# Altium schematic sheet CSI2-ZCU106-EVK-1_Rev01.SchDoc
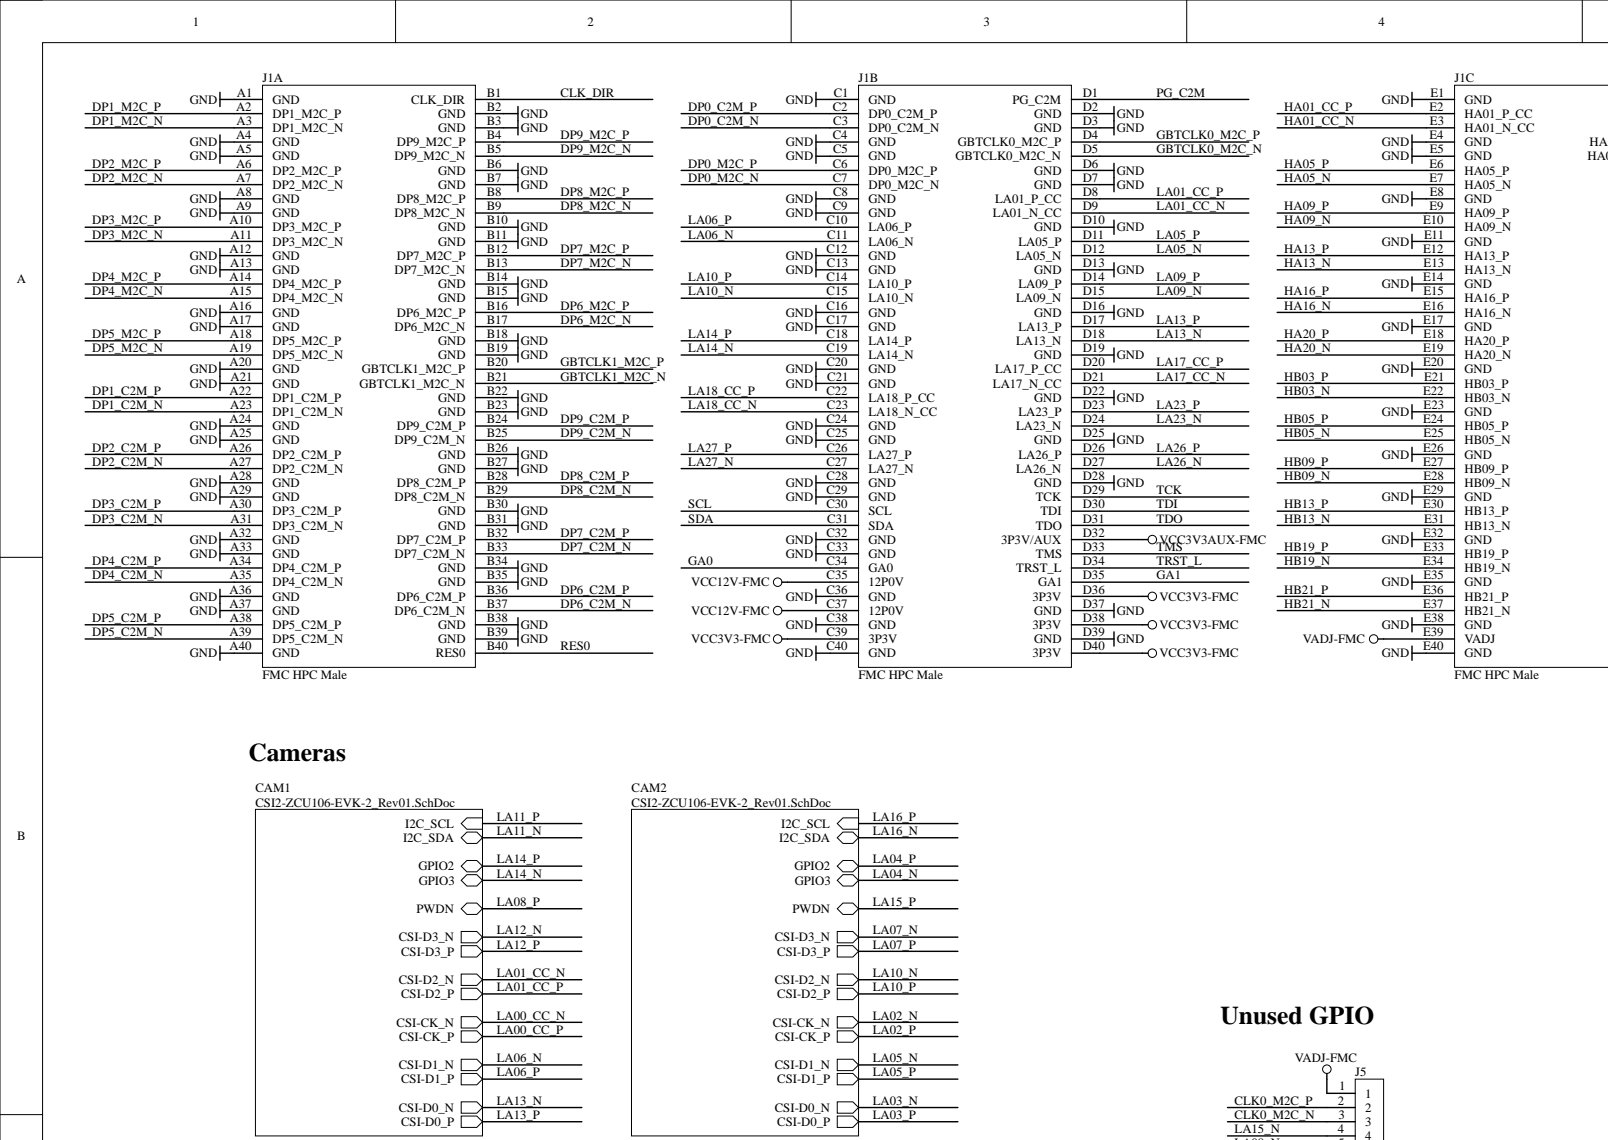
[diagram p0: CSI2-ZCU106-EVK-1_Rev01.SchDoc, top left]
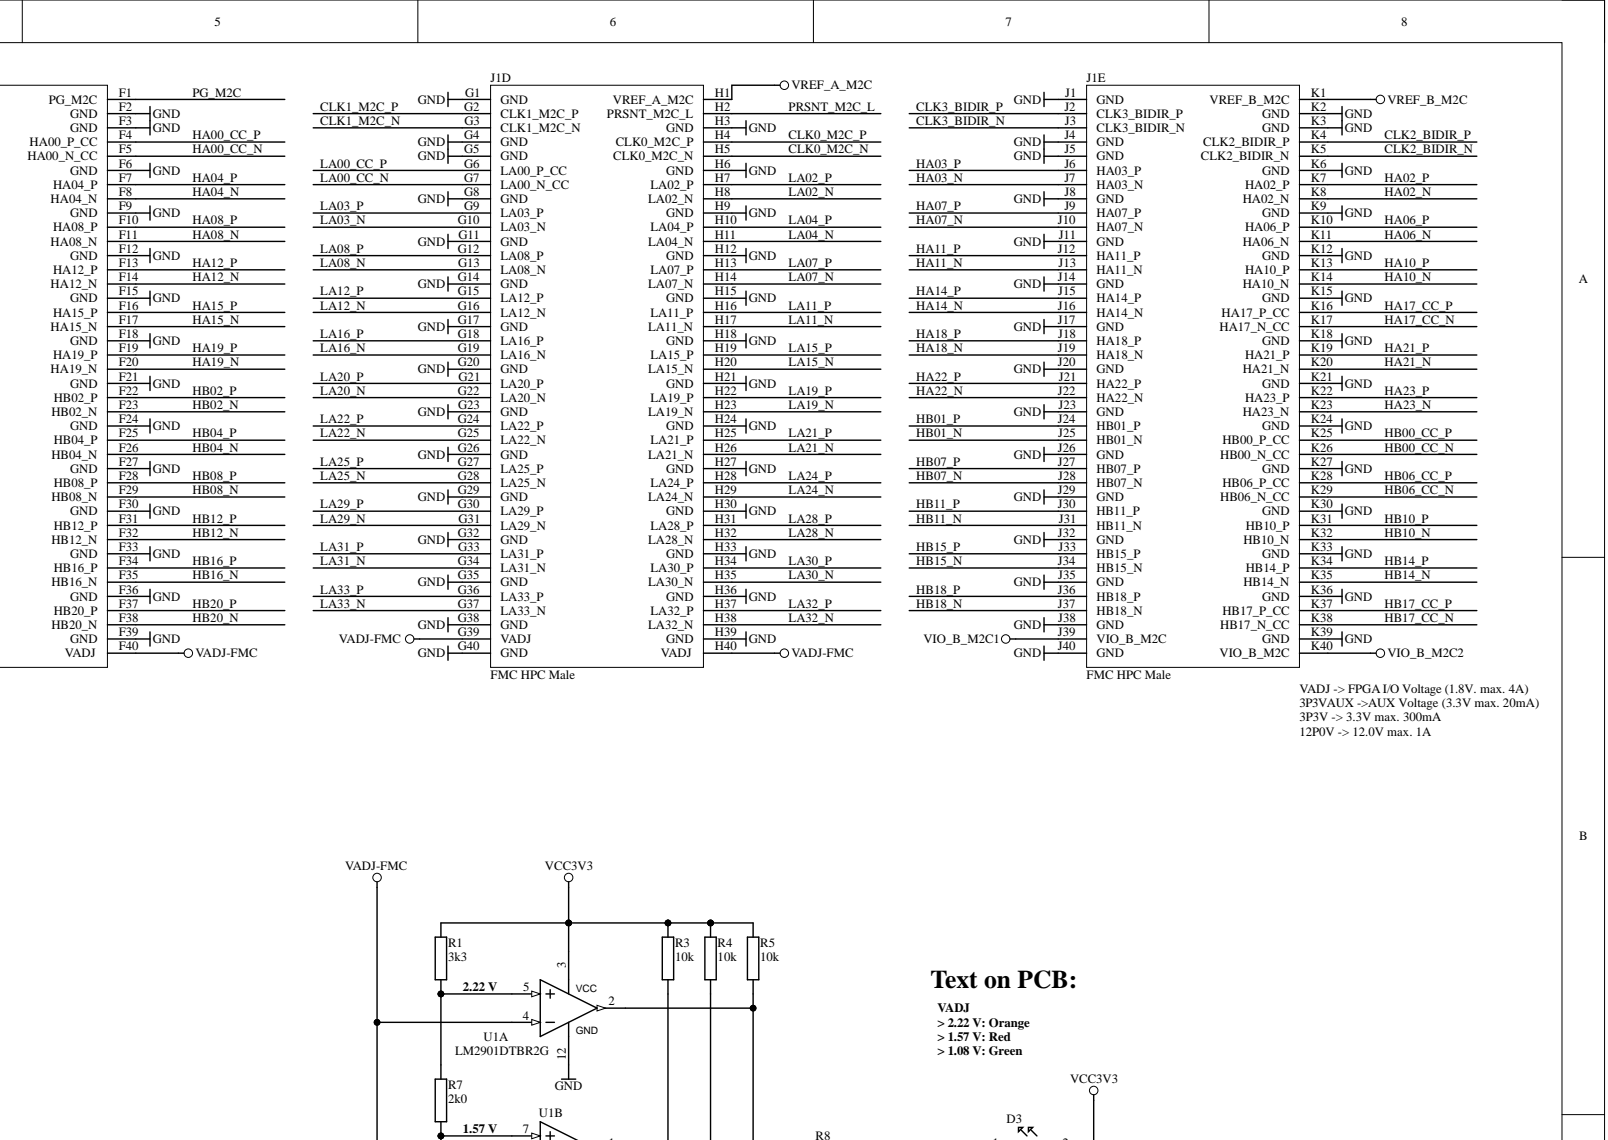
[diagram p1: CSI2-ZCU106-EVK-1_Rev01.SchDoc, top right]
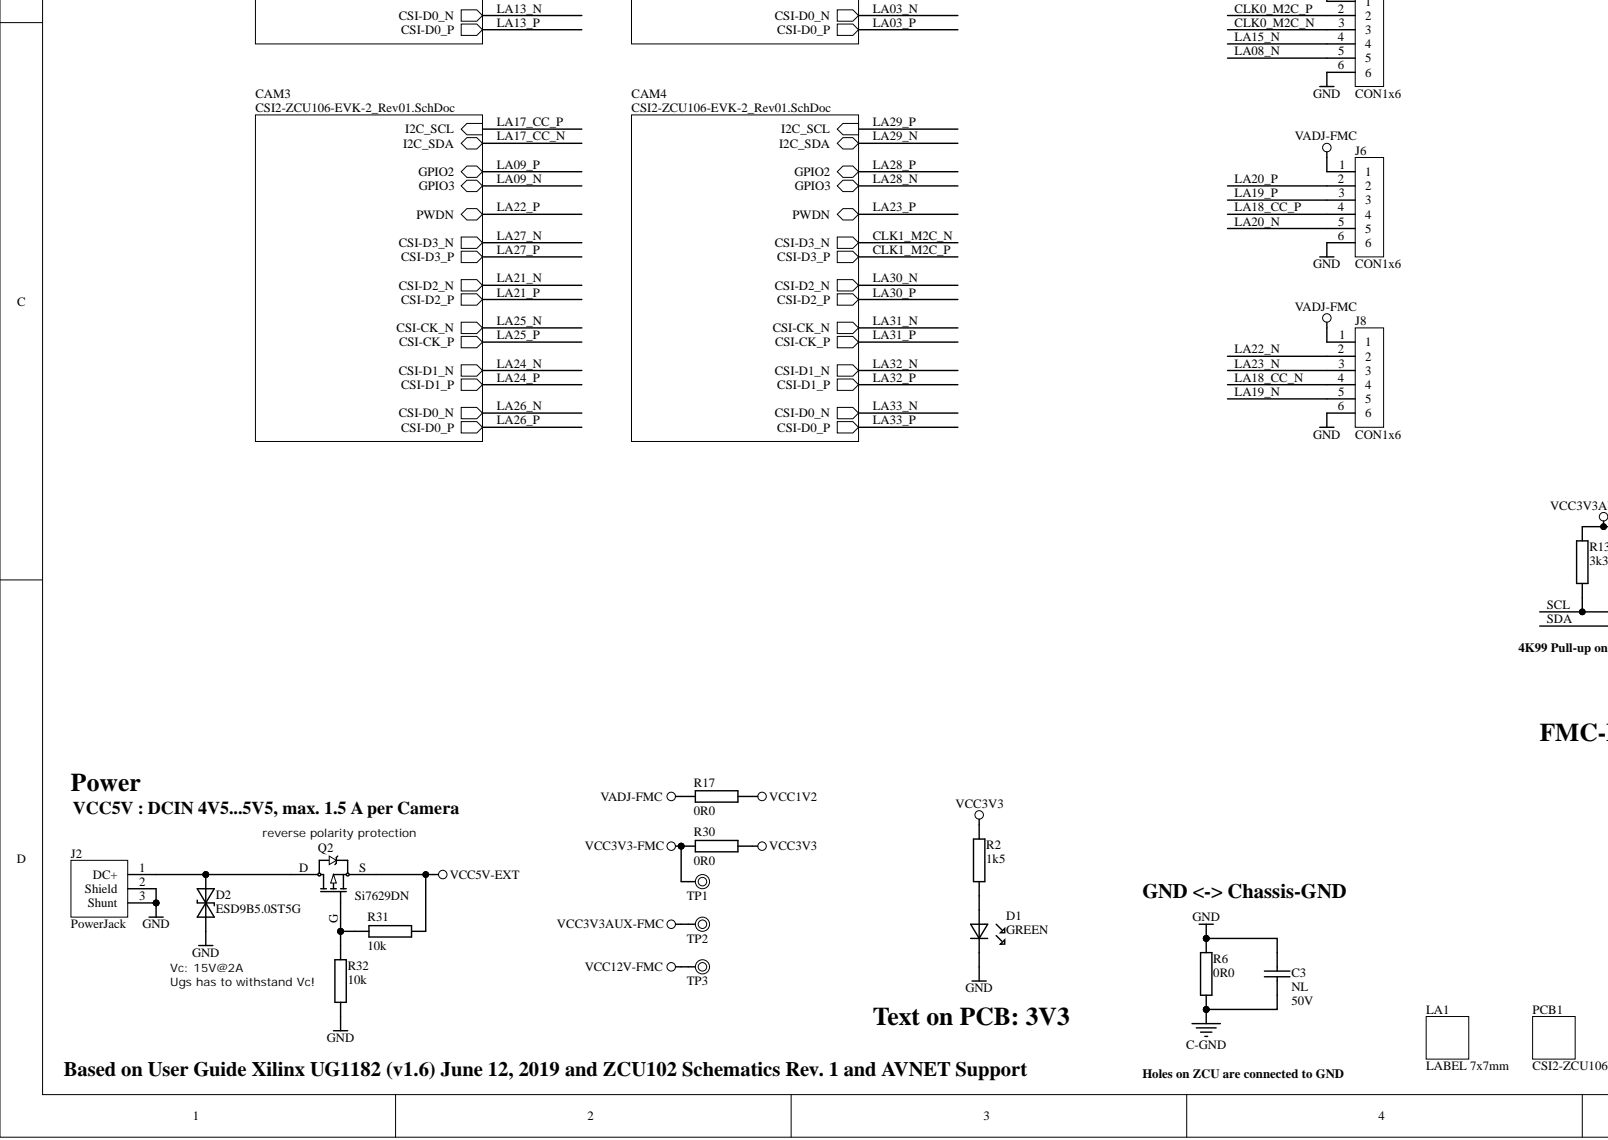
[diagram p2: CSI2-ZCU106-EVK-1_Rev01.SchDoc, bottom left]
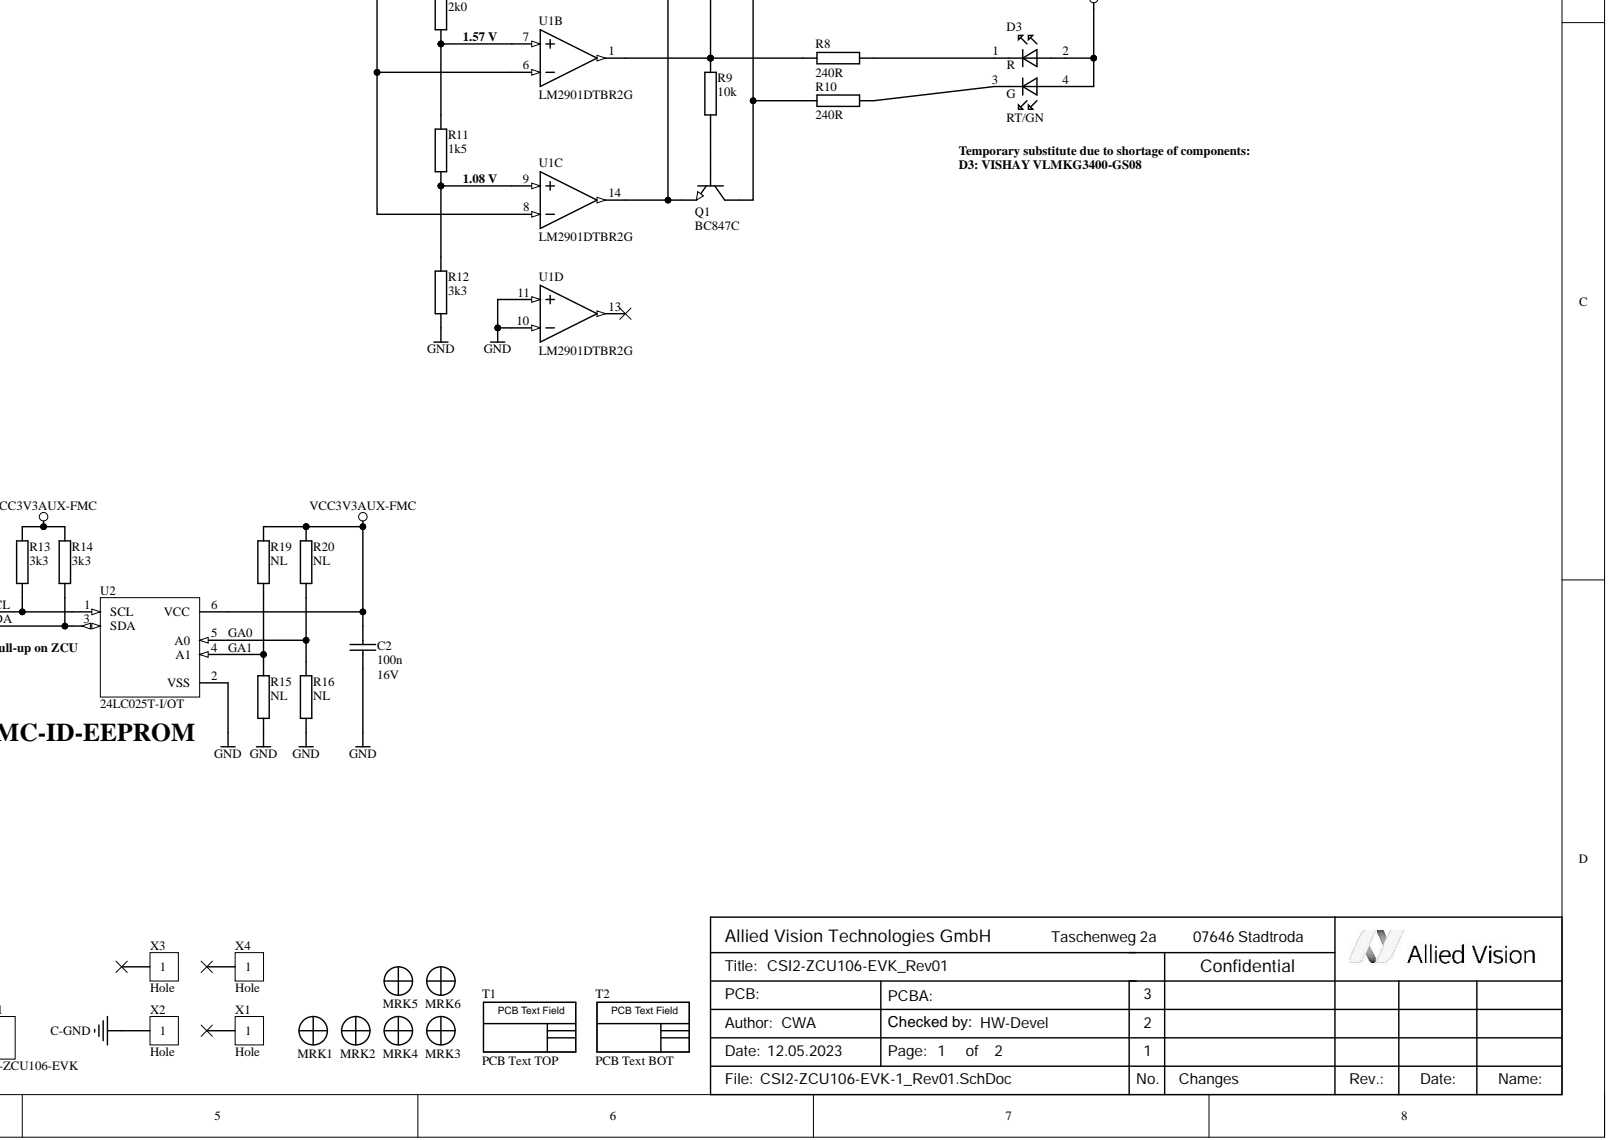
[diagram p3: CSI2-ZCU106-EVK-1_Rev01.SchDoc, bottom right]

## Parts
C2  100n  Voltage: 16V  [C-0402_0.55]
C3  NL  Voltage: 50V  [C-0603_1.0]
D1  GREEN  [D-0603-LED]
D2  ESD9B5.0ST5G  [D-SOD923]
D3  RT/GN  [LED-PLCC4]
J1  FMC HPC Male  [J-ASP-134488-01]  (parts A,B,C,D,E)
J2  PowerJack  [CON_RAPC722]
J5  CON1x6  [CON 1x6 RM2.54]
J6  CON1x6  [CON 1x6 RM2.54]
J8  CON1x6  [CON 1x6 RM2.54]
LA1  LABEL 7x7mm  [PCB LABEL 7x7]
MRK1  Marker Single Layer  [PCB_Marker_Pad_top]  (value not drawn)
MRK2  Marker Single Layer  [PCB_Marker_Pad_top]  (value not drawn)
MRK3  Marker Single Layer  [PCB_Marker_Pad_top]  (value not drawn)
MRK4  Marker Single Layer  [PCB_Marker_Pad_top]  (value not drawn)
MRK5  Marker Single Layer  [PCB_Marker_Pad_top]  (value not drawn)
MRK6  Marker Single Layer  [PCB_Marker_Pad_top]  (value not drawn)
PCB1  CSI2-ZCU106-EVK  (mechanical)
Q1  BC847C  [IC-SOT23-3]
Q2  Si7629DN  [IC-POWERPAK_1212-8_3.4x3.4x1.1]
R1  3k3  [R-0402_0.4]
R2  1k5  [R-0402_0.4]
R3  10k  [R-0402_0.4]
R4  10k  [R-0402_0.4]
R5  10k  [R-0402_0.4]
R6  0R0  [R-0603_0.6]
R7  2k0  [R-0402_0.4]
R8  240R  [R-0402_0.4]
R9  10k  [R-0402_0.4]
R10  240R  [R-0402_0.4]
R11  1k5  [R-0402_0.4]
R12  3k3  [R-0402_0.4]
R13  3k3  [R-0402_0.4]
R14  3k3  [R-0402_0.4]
R15  NL  [R-0603_0.6]
R16  NL  [R-0603_0.6]
R17  0R0  [R-0603_0.6]
R19  NL  [R-0603_0.6]
R20  NL  [R-0603_0.6]
R30  0R0  [R-0603_0.6]
R31  10k  [R-0402_0.4]
R32  10k  [R-0402_0.4]
T1  PCB Text TOP  [PCB TEXTFIELD TOP 2]  (no BOM)
T2  PCB Text BOT  [PCB TEXTFIELD BOT 2]  (no BOM)
TP1  TP  [TP_16_10]  (no BOM)
TP2  TP  [TP_16_10]  (no BOM)
TP3  TP  [TP_16_10]  (no BOM)
U1  LM2901DTBR2G  [IC-SO14_5.1x6.6x1.2]  (parts A,B,C,D)
U2  24LC025T-I/OT  [IC-SOT23-6]
X1  Hole  [HOLE-2.6-5.0-DK]  (no BOM)
X2  Hole  [HOLE-2.6-5.0-DK]  (no BOM)
X3  Hole  [HOLE-2.6-5.0-DK]  (no BOM)
X4  Hole  [HOLE-2.6-5.0-DK]  (no BOM)

## Nets
C-GND: C3.2 R6.1 X2.1
GND: C2.2 C3.1 D1.K D2.A J1.A1(GND) J1.A4(GND) J1.A5(GND) J1.A8(GND) J1.A9(GND) J1.A12(GND) J1.A13(GND) J1.A16(GND) J1.A17(GND) J1.A20(GND) J1.A21(GND) J1.A24(GND) J1.A25(GND) J1.A28(GND) J1.A29(GND) J1.A32(GND) J1.A33(GND) J1.A36(GND) J1.A37(GND) J1.A40(GND) J1.B2(GND) J1.B3(GND) J1.B6(GND) J1.B7(GND) J1.B10(GND) J1.B11(GND) J1.B14(GND) J1.B15(GND) J1.B18(GND) J1.B19(GND) J1.B22(GND) J1.B23(GND) J1.B26(GND) J1.B27(GND) J1.B30(GND) J1.B31(GND) J1.B34(GND) J1.B35(GND) J1.B38(GND) J1.B39(GND) J1.C1(GND) J1.C4(GND) J1.C5(GND) J1.C8(GND) J1.C9(GND) J1.C12(GND) J1.C13(GND) J1.C16(GND) J1.C17(GND) J1.C20(GND) J1.C21(GND) J1.C24(GND) J1.C25(GND) J1.C28(GND) J1.C29(GND) J1.C32(GND) J1.C33(GND) J1.C36(GND) J1.C38(GND) J1.C40(GND) J1.D2(GND) J1.D3(GND) J1.D6(GND) J1.D7(GND) J1.D10(GND) J1.D13(GND) J1.D16(GND) J1.D19(GND) J1.D22(GND) J1.D25(GND) J1.D28(GND) J1.D37(GND) J1.D39(GND) J1.E1(GND) J1.E4(GND) J1.E5(GND) J1.E8(GND) J1.E11(GND) J1.E14(GND) J1.E17(GND) J1.E20(GND) J1.E23(GND) J1.E26(GND) J1.E29(GND) J1.E32(GND) J1.E35(GND) J1.E38(GND) J1.E40(GND) J1.F2(GND) J1.F3(GND) J1.F6(GND) J1.F9(GND) J1.F12(GND) J1.F15(GND) J1.F18(GND) J1.F21(GND) J1.F24(GND) J1.F27(GND) J1.F30(GND) J1.F33(GND) J1.F36(GND) J1.F39(GND) J1.G1(GND) J1.G4(GND) J1.G5(GND) J1.G8(GND) J1.G11(GND) J1.G14(GND) J1.G17(GND) J1.G20(GND) J1.G23(GND) J1.G26(GND) J1.G29(GND) J1.G32(GND) J1.G35(GND) J1.G38(GND) J1.G40(GND) J1.H3(GND) J1.H6(GND) J1.H9(GND) J1.H12(GND) J1.H15(GND) J1.H18(GND) J1.H21(GND) J1.H24(GND) J1.H27(GND) J1.H30(GND) J1.H33(GND) J1.H36(GND) J1.H39(GND) J1.J1(GND) J1.J4(GND) J1.J5(GND) J1.J8(GND) J1.J11(GND) J1.J14(GND) J1.J17(GND) J1.J20(GND) J1.J23(GND) J1.J26(GND) J1.J29(GND) J1.J32(GND) J1.J35(GND) J1.J38(GND) J1.J40(GND) J1.K2(GND) J1.K3(GND) J1.K6(GND) J1.K9(GND) J1.K12(GND) J1.K15(GND) J1.K18(GND) J1.K21(GND) J1.K24(GND) J1.K27(GND) J1.K30(GND) J1.K33(GND) J1.K36(GND) J1.K39(GND) J2.2 J2.3 J5.6 J6.6 J8.6 R6.2 R12.2 R15.2 R16.2 R32.1 U1.10 U1.11 U1.12 U2.2(VSS)
VADJ-FMC: J1.E39(VADJ) J1.F40(VADJ) J1.G39(VADJ) J1.H40(VADJ) J5.1 J6.1 J8.1 R17.1 U1.4 U1.6 U1.8
VCC1V2: R17.2
VCC3V3: D3.2 D3.4 R1.1 R2.1 R3.1 R4.1 R5.1 R30.2 U1.3
VCC3V3-FMC: J1.C39(3P3V) J1.D36(3P3V) J1.D38(3P3V) J1.D40(3P3V) R30.1 TP1.1
VCC3V3AUX-FMC: C2.1 J1.D32(3P3V/AUX) R13.2 R14.2 R19.1 R20.1 TP2.1 U2.6(VCC)
VCC5V-EXT: Q2.S R31.1
VCC12V-FMC: J1.C35(12P0V) J1.C37(12P0V) TP3.1
VIO_B_M2C1: J1.J39(VIO_B_M2C)
VIO_B_M2C2: J1.K40(VIO_B_M2C)
VREF_A_M2C: J1.H1(VREF_A_M2C)
VREF_B_M2C: J1.K1(VREF_B_M2C)
CLK0_M2C_N: J1.H5(CLK0_M2C_N) J5.3
CLK0_M2C_P: J1.H4(CLK0_M2C_P) J5.2
CLK1_M2C_N: J1.G3(CLK1_M2C_N)
CLK1_M2C_P: J1.G2(CLK1_M2C_P)
CLK2_BIDIR_N: J1.K5(CLK2_BIDIR_N)
CLK2_BIDIR_P: J1.K4(CLK2_BIDIR_P)
CLK3_BIDIR_N: J1.J3(CLK3_BIDIR_N)
CLK3_BIDIR_P: J1.J2(CLK3_BIDIR_P)
CLK_DIR: J1.B1(CLK_DIR)
DP0_C2M_N: J1.C3(DP0_C2M_N)
DP0_C2M_P: J1.C2(DP0_C2M_P)
DP0_M2C_N: J1.C7(DP0_M2C_N)
DP0_M2C_P: J1.C6(DP0_M2C_P)
DP1_C2M_N: J1.A23(DP1_C2M_N)
DP1_C2M_P: J1.A22(DP1_C2M_P)
DP1_M2C_N: J1.A3(DP1_M2C_N)
DP1_M2C_P: J1.A2(DP1_M2C_P)
DP2_C2M_N: J1.A27(DP2_C2M_N)
DP2_C2M_P: J1.A26(DP2_C2M_P)
DP2_M2C_N: J1.A7(DP2_M2C_N)
DP2_M2C_P: J1.A6(DP2_M2C_P)
DP3_C2M_N: J1.A31(DP3_C2M_N)
DP3_C2M_P: J1.A30(DP3_C2M_P)
DP3_M2C_N: J1.A11(DP3_M2C_N)
DP3_M2C_P: J1.A10(DP3_M2C_P)
DP4_C2M_N: J1.A35(DP4_C2M_N)
DP4_C2M_P: J1.A34(DP4_C2M_P)
DP4_M2C_N: J1.A15(DP4_M2C_N)
DP4_M2C_P: J1.A14(DP4_M2C_P)
DP5_C2M_N: J1.A39(DP5_C2M_N)
DP5_C2M_P: J1.A38(DP5_C2M_P)
DP5_M2C_N: J1.A19(DP5_M2C_N)
DP5_M2C_P: J1.A18(DP5_M2C_P)
DP6_C2M_N: J1.B37(DP6_C2M_N)
DP6_C2M_P: J1.B36(DP6_C2M_P)
DP6_M2C_N: J1.B17(DP6_M2C_N)
DP6_M2C_P: J1.B16(DP6_M2C_P)
DP7_C2M_N: J1.B33(DP7_C2M_N)
DP7_C2M_P: J1.B32(DP7_C2M_P)
DP7_M2C_N: J1.B13(DP7_M2C_N)
DP7_M2C_P: J1.B12(DP7_M2C_P)
DP8_C2M_N: J1.B29(DP8_C2M_N)
DP8_C2M_P: J1.B28(DP8_C2M_P)
DP8_M2C_N: J1.B9(DP8_M2C_N)
DP8_M2C_P: J1.B8(DP8_M2C_P)
DP9_C2M_N: J1.B25(DP9_C2M_N)
DP9_C2M_P: J1.B24(DP9_C2M_P)
DP9_M2C_N: J1.B5(DP9_M2C_N)
DP9_M2C_P: J1.B4(DP9_M2C_P)
GA0: J1.C34(GA0) R16.1 R20.2 U2.5(A0)
GA1: J1.D35(GA1) R15.1 R19.2 U2.4(A1)
GBTCLK0_M2C_N: J1.D5(GBTCLK0_M2C_N)
GBTCLK0_M2C_P: J1.D4(GBTCLK0_M2C_P)
GBTCLK1_M2C_N: J1.B21(GBTCLK1_M2C_N)
GBTCLK1_M2C_P: J1.B20(GBTCLK1_M2C_P)
HA00_CC_N: J1.F5(HA00_N_CC)
HA00_CC_P: J1.F4(HA00_P_CC)
HA01_CC_N: J1.E3(HA01_N_CC)
HA01_CC_P: J1.E2(HA01_P_CC)
HA02_N: J1.K8(HA02_N)
HA02_P: J1.K7(HA02_P)
HA03_N: J1.J7(HA03_N)
HA03_P: J1.J6(HA03_P)
HA04_N: J1.F8(HA04_N)
HA04_P: J1.F7(HA04_P)
HA05_N: J1.E7(HA05_N)
HA05_P: J1.E6(HA05_P)
HA06_N: J1.K11(HA06_N)
HA06_P: J1.K10(HA06_P)
HA07_N: J1.J10(HA07_N)
HA07_P: J1.J9(HA07_P)
HA08_N: J1.F11(HA08_N)
HA08_P: J1.F10(HA08_P)
HA09_N: J1.E10(HA09_N)
HA09_P: J1.E9(HA09_P)
HA10_N: J1.K14(HA10_N)
HA10_P: J1.K13(HA10_P)
HA11_N: J1.J13(HA11_N)
HA11_P: J1.J12(HA11_P)
HA12_N: J1.F14(HA12_N)
HA12_P: J1.F13(HA12_P)
HA13_N: J1.E13(HA13_N)
HA13_P: J1.E12(HA13_P)
HA14_N: J1.J16(HA14_N)
HA14_P: J1.J15(HA14_P)
HA15_N: J1.F17(HA15_N)
HA15_P: J1.F16(HA15_P)
HA16_N: J1.E16(HA16_N)
HA16_P: J1.E15(HA16_P)
HA17_CC_N: J1.K17(HA17_N_CC)
HA17_CC_P: J1.K16(HA17_P_CC)
HA18_N: J1.J19(HA18_N)
HA18_P: J1.J18(HA18_P)
HA19_N: J1.F20(HA19_N)
HA19_P: J1.F19(HA19_P)
HA20_N: J1.E19(HA20_N)
HA20_P: J1.E18(HA20_P)
HA21_N: J1.K20(HA21_N)
HA21_P: J1.K19(HA21_P)
HA22_N: J1.J22(HA22_N)
HA22_P: J1.J21(HA22_P)
HA23_N: J1.K23(HA23_N)
HA23_P: J1.K22(HA23_P)
HB00_CC_N: J1.K26(HB00_N_CC)
HB00_CC_P: J1.K25(HB00_P_CC)
HB01_N: J1.J25(HB01_N)
HB01_P: J1.J24(HB01_P)
HB02_N: J1.F23(HB02_N)
HB02_P: J1.F22(HB02_P)
HB03_N: J1.E22(HB03_N)
HB03_P: J1.E21(HB03_P)
HB04_N: J1.F26(HB04_N)
HB04_P: J1.F25(HB04_P)
HB05_N: J1.E25(HB05_N)
HB05_P: J1.E24(HB05_P)
HB06_CC_N: J1.K29(HB06_N_CC)
HB06_CC_P: J1.K28(HB06_P_CC)
HB07_N: J1.J28(HB07_N)
HB07_P: J1.J27(HB07_P)
HB08_N: J1.F29(HB08_N)
HB08_P: J1.F28(HB08_P)
HB09_N: J1.E28(HB09_N)
HB09_P: J1.E27(HB09_P)
HB10_N: J1.K32(HB10_N)
HB10_P: J1.K31(HB10_P)
HB11_N: J1.J31(HB11_N)
HB11_P: J1.J30(HB11_P)
HB12_N: J1.F32(HB12_N)
HB12_P: J1.F31(HB12_P)
HB13_N: J1.E31(HB13_N)
HB13_P: J1.E30(HB13_P)
HB14_N: J1.K35(HB14_N)
HB14_P: J1.K34(HB14_P)
HB15_N: J1.J34(HB15_N)
HB15_P: J1.J33(HB15_P)
HB16_N: J1.F35(HB16_N)
HB16_P: J1.F34(HB16_P)
HB17_CC_N: J1.K38(HB17_N_CC)
HB17_CC_P: J1.K37(HB17_P_CC)
HB18_N: J1.J37(HB18_N)
HB18_P: J1.J36(HB18_P)
HB19_N: J1.E34(HB19_N)
HB19_P: J1.E33(HB19_P)
HB20_N: J1.F38(HB20_N)
HB20_P: J1.F37(HB20_P)
HB21_N: J1.E37(HB21_N)
HB21_P: J1.E36(HB21_P)
LA00_CC_N: J1.G7(LA00_N_CC)
LA00_CC_P: J1.G6(LA00_P_CC)
LA01_CC_N: J1.D9(LA01_N_CC)
LA01_CC_P: J1.D8(LA01_P_CC)
LA02_N: J1.H8(LA02_N)
LA02_P: J1.H7(LA02_P)
LA03_N: J1.G10(LA03_N)
LA03_P: J1.G9(LA03_P)
LA04_N: J1.H11(LA04_N)
LA04_P: J1.H10(LA04_P)
LA05_N: J1.D12(LA05_N)
LA05_P: J1.D11(LA05_P)
LA06_N: J1.C11(LA06_N)
LA06_P: J1.C10(LA06_P)
LA07_N: J1.H14(LA07_N)
LA07_P: J1.H13(LA07_P)
LA08_N: J1.G13(LA08_N) J5.5
LA08_P: J1.G12(LA08_P)
LA09_N: J1.D15(LA09_N)
LA09_P: J1.D14(LA09_P)
LA10_N: J1.C15(LA10_N)
LA10_P: J1.C14(LA10_P)
LA11_N: J1.H17(LA11_N)
LA11_P: J1.H16(LA11_P)
LA12_N: J1.G16(LA12_N)
LA12_P: J1.G15(LA12_P)
LA13_N: J1.D18(LA13_N)
LA13_P: J1.D17(LA13_P)
LA14_N: J1.C19(LA14_N)
LA14_P: J1.C18(LA14_P)
LA15_N: J1.H20(LA15_N) J5.4
LA15_P: J1.H19(LA15_P)
LA16_N: J1.G19(LA16_N)
LA16_P: J1.G18(LA16_P)
LA17_CC_N: J1.D21(LA17_N_CC)
LA17_CC_P: J1.D20(LA17_P_CC)
LA18_CC_N: J1.C23(LA18_N_CC) J8.4
LA18_CC_P: J1.C22(LA18_P_CC) J6.4
LA19_N: J1.H23(LA19_N) J8.5
LA19_P: J1.H22(LA19_P) J6.3
LA20_N: J1.G22(LA20_N) J6.5
LA20_P: J1.G21(LA20_P) J6.2
LA21_N: J1.H26(LA21_N)
LA21_P: J1.H25(LA21_P)
LA22_N: J1.G25(LA22_N) J8.2
LA22_P: J1.G24(LA22_P)
LA23_N: J1.D24(LA23_N) J8.3
LA23_P: J1.D23(LA23_P)
LA24_N: J1.H29(LA24_N)
LA24_P: J1.H28(LA24_P)
LA25_N: J1.G28(LA25_N)
LA25_P: J1.G27(LA25_P)
LA26_N: J1.D27(LA26_N)
LA26_P: J1.D26(LA26_P)
LA27_N: J1.C27(LA27_N)
LA27_P: J1.C26(LA27_P)
LA28_N: J1.H32(LA28_N)
LA28_P: J1.H31(LA28_P)
LA29_N: J1.G31(LA29_N)
LA29_P: J1.G30(LA29_P)
LA30_N: J1.H35(LA30_N)
LA30_P: J1.H34(LA30_P)
LA31_N: J1.G34(LA31_N)
LA31_P: J1.G33(LA31_P)
LA32_N: J1.H38(LA32_N)
LA32_P: J1.H37(LA32_P)
LA33_N: J1.G37(LA33_N)
LA33_P: J1.G36(LA33_P)
PG_C2M: J1.D1(PG_C2M)
PG_M2C: J1.F1(PG_M2C)
PRSNT_M2C_L: J1.H2(PRSNT_M2C_L)
RES0: J1.B40(RES0)
SCL: J1.C30(SCL) R13.1 U2.1(SCL)
SDA: J1.C31(SDA) R14.1 U2.3(SDA)
TCK: J1.D29(TCK)
TDI: J1.D30(TDI)
TDO: J1.D31(TDO)
TMS: J1.D33(TMS)
TRST_L: J1.D34(TRST_L)
NetD1_A: D1.A R2.2
NetD2_K: D2.K J2.1 Q2.D
NetD3_1: D3.1 R8.2
NetD3_3: D3.3 R10.2
NetQ1_1: Q1.1 R9.2
NetQ1_2: Q1.2 R3.2 U1.14
NetQ1_3: Q1.3 R5.2 R10.1 U1.2
NetQ2_G: Q2.G R31.2 R32.2
NetR1_2: R1.2 R7.1 U1.5
NetR4_2: R4.2 R8.1 R9.1 U1.1
NetR7_2: R7.2 R11.1 U1.7
NetR11_2: R11.2 R12.1 U1.9
NetU1_13: U1.13
NetX1_1: X1.1
NetX3_1: X3.1
NetX4_1: X4.1

## Library data of the parts
C2 = C 100N 10% 16V X7R 402: Capacitor Ceramic SMD/0402/16V/X7R/10%; KHK Part Number: K8340114; Manufacturer: several; Dielectric: X7R; Tolerance: 10%; Component Kind: Standard; Library Name: Passive.SCHLIB; Manufacturer Part Number: several; Supplier 1: Digi-Key; Supplier 2: Digi-Key; Supplier Part Number 1: 1276-1508-1-ND; Supplier Part Number 2: 311-1338-1-ND
C3 = C X 0603: Capacitor Ceramic SMD/0603/50V/X7R/10%; Manufacturer: several; Dielectric: X7R; Tolerance: 10%; Component Kind: Standard; Manufacturer Part Number: several; Library Name: AVT_KHK_Lib.IntLib
D1 = D LED 0603 green: Chip LED green 430mcd; KHK Part Number: 8172; Manufacturer: Würth; Manufacturer Part Number: 150060GS75000; Current: 20mA; Color: green; Component Kind: Standard; Supplier 1: Digi-Key; Supplier Part Number 1: 732-4971-1-ND; Library Name: AVT_KHK_Lib.IntLib
D2 = D ESD9B5.0ST5G: DIODE TVS; 400W; 5.8-7.8V; BIDIRECT; KHK Part Number: 7250; Manufacturer: ON Semiconductor; Component Kind: Standard; Manufacturer Part Number: ESD9B5.0ST5G; Supplier 1: Digi-Key; Supplier Part Number 1: ESD9B5.0ST5GOSCT-ND; Library Name: AVT_KHK_Lib.IntLib
D3 = D LSG T67K-JL-1+HK-1: LED red/green; KHK Part Number: 11105; Manufacturer: OSRAM; Component Kind: Standard; Library Name: Bipolar.SCHLIB; Manufacturer Part Number: LSG T67K-JL-1+HK-1; Supplier 1: Digi-Key; Supplier Part Number 1: 475-3435-1-ND
J1 = CON FMC HPC Male: CON FMC HPC MALE 10MM; KHK Part Number: 9159; Manufacturer: Samtec; Manufacturer Part Number: ASP-134488-01; Component Kind: Standard; Library Name: Connector.SCHLIB; Supplier 1: Digi-Key; Supplier Part Number 1: SAM8730-ND
J2 = CON RAPC722: Switchcraft PowerJack RAPC722 / 2.1mm (Inner) / 6mm (Outer); KHK Part Number: K7600556; Manufacturer Part Number: RAPC722X; Component Kind: Standard; Manufacturer: Switchcraft; Supplier 1: Digi-Key; Supplier Part Number 1: SC1313-ND; Library Name: AVT_KHK_Lib.IntLib
J5 J6 J8 = CON 1x6 2.54 several: Header Vertical, 1x6 Pos, Pitch 2.54mm, Contact Length Mating 6.00mm, Contact Length Post 3.00mm, Insulation Height 2.54mm, Gold Plating; KHK Part Number: 15686; Component Kind: Standard; Library Name: Connector.SCHLIB; Manufacturer: several; Manufacturer Part Number: several; Supplier 1: Digi-Key; Supplier Part Number 1: 732-5319-ND
LA1 = PCB LABEL 7x7: Manufacturer: several; Component Kind: Standard; Description: LABEL 7x7mm; Library Name: Misc.SCHLIB; Manufacturer Part Number: several
MRK1-MRK6 = PCB Marker Pad Single Layer: Position Marker for PCBs; Component Kind: Standard; Library Name: AVT_KHK_Lib.IntLib
PCB1 = PCB: PCB ML04SF; KHK Part Number: 14393-01; Manufacturer: several; Manufacturer Part Number: 14393-01; Library Name: AVT_KHK_Lib.IntLib
Q1 = Q BC847C SOT23: TRANSISTOR NPN 100mA 45V; KHK Part Number: 16587; Manufacturer: several; Component Kind: Standard; Library Name: Bipolar.SCHLIB; Manufacturer Part Number: BC847C; Supplier 1: Digi-Key; Supplier Part Number 1: BC847CE6327HTSA1CT-ND
Q2 = Q Si7629DN: P-Channel MOSFET 20V; KHK Part Number: 3619; Manufacturer: Vishay; Component Kind: Standard; Manufacturer Part Number: Si7629DN; Supplier 1: Digi-Key; Supplier Part Number 1: SI7629DN-T1-GE3CT-ND; Library Name: AVT_KHK_Lib.IntLib
R1 R12-R14 = R 3k3 1% 0402: Standard Resistor SMD/0402/1%/63mW/TK100; KHK Part Number: K8250347; Manufacturer: several; Temperature Coefficient: TK100; Tolerance: 1%; Component Kind: Standard; Manufacturer Part Number: several; Supplier 1: Digi-Key; Supplier Part Number 1: 311-3.30KLRCT-ND; Library Name: AVT_KHK_Lib.IntLib
R2 R11 = R 1K5 1% 0402: Standard Resistor SMD/0402/1%/63mW/TK100; KHK Part Number: K8250358; Manufacturer: several; Tolerance: 1%; Component Kind: Standard; Manufacturer Part Number: several; Supplier 1: Digi-Key; Supplier Part Number 1: 311-1.50KLRCT-ND
  R11: Library Name: Passive.SCHLIB
  R2: Library Name: AVT_KHK_Lib.IntLib
R3-R5 R9 R31 R32 = R 10K 1% 0402: Standard Resistor SMD/0402/1%/63mW/TK100; KHK Part Number: K8250264; Manufacturer: several; Temperature Coefficient: TK100; Tolerance: 1%; Component Kind: Standard; Library Name: Passive.SCHLIB; Manufacturer Part Number: several; Supplier 1: Digi-Key; Supplier 2: Farnell; Supplier 3: RSComponents; Supplier 4: Digi-Key; Supplier Part Number 1: CR0402-FX-1002GLFCT-ND; Supplier Part Number 2: 2421890; Supplier Part Number 3: 6678848P; Supplier Part Number 4: 541-10.0KLCT-ND
R6 R17 R30 = R 0R0 1% 0603: Standard Resistor SMD/0603/1%/100mW/TK200; KHK Part Number: K8250041; Manufacturer: several; Tolerance: 1%; Component Kind: Standard; Library Name: Passive.SCHLIB; Manufacturer Part Number: several; Supplier 1: Digi-Key; Supplier Part Number 1: 311-0.0HRCT-ND
R7 = R 2k0 1% 0402: Standard Resistor SMD/0402/1%/63mW/TK100; KHK Part Number: K8250311; Manufacturer: several; Temperature Coefficient: TK100; Tolerance: 1%; Component Kind: Standard; Library Name: Passive.SCHLIB; Manufacturer Part Number: several; Supplier 1: Digi-Key; Supplier Part Number 1: 311-2KLRCT-ND
R8 R10 = R 240R 1% 0402: Standard Resistor SMD/0402/1%/63mW/TK100; KHK Part Number: 7256; Manufacturer: several; Temperature Coefficient: TK100; Tolerance: 1%; Component Kind: Standard; Manufacturer Part Number: several; Supplier 1: Digi-Key; Supplier Part Number 1: YAG3461CT-ND; Library Name: AVT_KHK_Lib.IntLib
R15 R16 R19 R20 = R X 0603: Standard Resistor SMD/0603/1%/100mW/TK200; Temperature Coefficient: TK100; Tolerance: 1%; Component Kind: Standard; Library Name: AVT_KHK_Lib.IntLib
T1 = PCB TEXT FIELD TOP: PCB Textfield TOP; Library Name: AVT_KHK_Lib.IntLib
T2 = PCB TEXT FIELD BOT: PCB Textfield BOT; Library Name: AVT_KHK_Lib.IntLib
TP1-TP3 = TP_16_10: Testpoint: Pad=1,6mm; Hole=1,0mm; Library Name: AVT_KHK_Lib.IntLib
U1 = IC LM2901DTBR2G: QUAD COMPERATOR; Manufacturer: ON Semi; Component Kind: Standard; Library Name: Amp.SCHLIB; Manufacturer Part Number: LM2901DTBR2G; Supplier 1: Digi-Key; Supplier Part Number 1: LM2901DTBR2GOSCT-ND
U2 = IC 24LC025T-I/OT: 2K I2C Serial EEPROM 2V5-5V5; KHK Part Number: 9217; Manufacturer: Microchip; Component Kind: Standard; Library Name: Memory.SCHLIB; Manufacturer Part Number: 24LC025T-I/OT; Supplier 1: Digi-Key; Supplier Part Number 1: 24LC025T-I/OTCT-ND
X1-X4 = PCB HOLE M2.5-5-DK: HOLE M2.5; Library Name: AVT_KHK_Lib.IntLib
